# Altium schematic sheet Proximity Sensors.SchDoc("U_Proximity Sensors4")
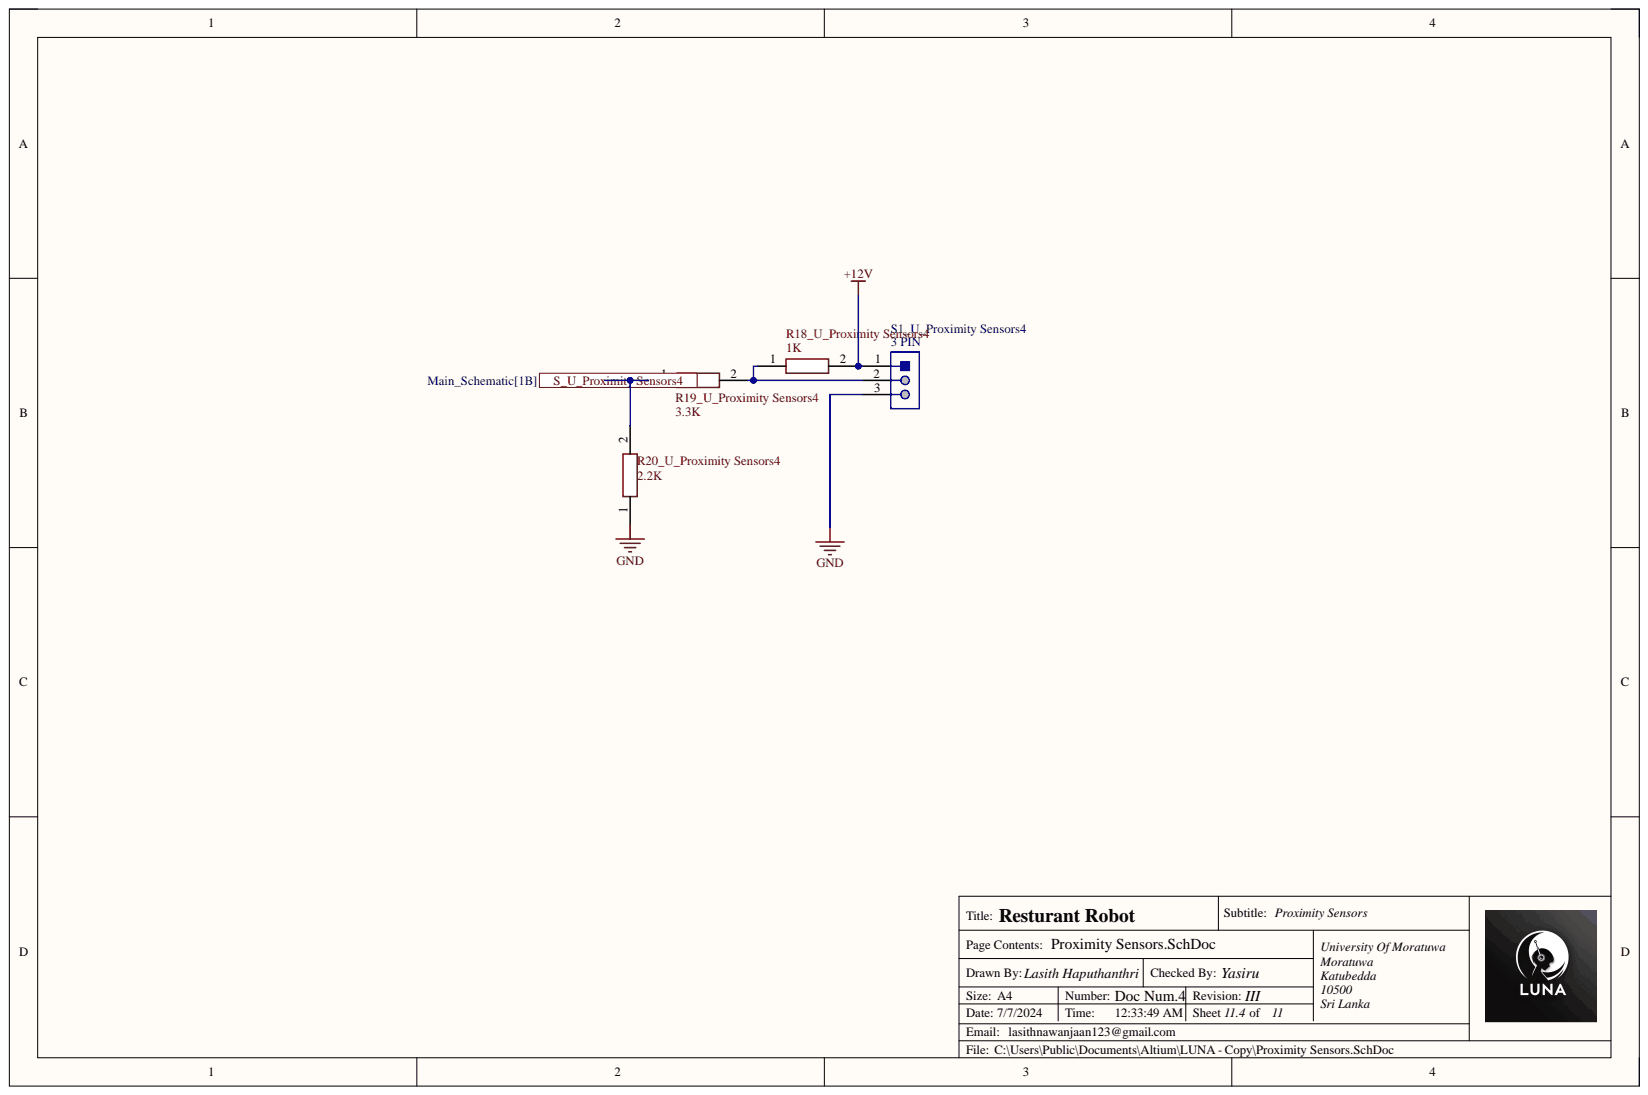
[diagram p0: Proximity Sensors.SchDoc]

## Parts
R18_U_Proximity_Sensors4  1K  [RESC1608X55N]
R19_U_Proximity_Sensors4  3.3K  [RESC1608X55N]
R20_U_Proximity_Sensors4  2.2K  [ERJ3EKF1000V]
S1_U_Proximity_Sensors4  3 PIN  [TECO-171825-3_V]

## Ports
S_U_Proximity Sensors4

## Nets
+12V: R18_U_Proximity_Sensors4.2 S1_U_Proximity_Sensors4.1
GND: R20_U_Proximity_Sensors4.1 S1_U_Proximity_Sensors4.3
NetR18_U_Proximity Sensors4_1: R18_U_Proximity_Sensors4.1 R19_U_Proximity_Sensors4.2 S1_U_Proximity_Sensors4.2
NetR19_U_Proximity Sensors4_1: R19_U_Proximity_Sensors4.1 R20_U_Proximity_Sensors4.2

## Library data of the parts
R18_U_Proximity_Sensors4 = RC0603FR-071KL: Resistor; Manufacturer_Name: YAGEO; Arrow Part Number: RC0603FR-071KL; Arrow Price/Stock: https://www.arrow.com/en/products/rc0603fr-071kl/yageo?region=nac; Component Kind: Standard; Datasheet Link: https://www.yageo.com/upload/media/product/productsearch/datasheet/rchip/PYu-RC_Group_51_RoHS_L_12.pdf; Height: 0.55mm; Library Name: SamacSys.SchLib; Manufacturer_Part_Number: RC0603FR-071KL; Mouser Part Number: 603-RC0603FR-071KL; Mouser Price/Stock: https://www.mouser.co.uk/ProductDetail/YAGEO/RC0603FR-071KL?qs=VU8sRB4EgwApHsk4rF%2F3zg%3D%3D
R19_U_Proximity_Sensors4 = RMCF0603JT3K30: Resistor RMCF0603JT3K30; Manufacturer_Name: Stackpole Electronics; Component Kind: Standard; Datasheet Link: https://www.seielect.com/Catalog/SEI-RMCF_RMCP.pdf; Height: 0.55mm; Manufacturer_Part_Number: RMCF0603JT3K30
R20_U_Proximity_Sensors4 = ERJ3GEYJ222V: Resistor; Manufacturer_Name: Panasonic; Arrow Part Number: ERJ3GEYJ222V; Arrow Price/Stock: https://www.arrow.com/en/products/erj-3geyj222v/panasonic?region=nac; Component Kind: Standard; Datasheet Link: http://docs-europe.electrocomponents.com/webdocs/13ba/0900766b813ba21b.pdf; Height: 0.55mm; Manufacturer_Part_Number: ERJ3GEYJ222V
S1_U_Proximity_Sensors4 = CMP-2000-07494-1: Male Header, Pitch 2.5 mm, 1 x 3 Position, Height 10.4 mm, Tail Length 3.6 mm,   -20 to 95 degC  , RoHS, Bulk; Manufacturer: TE Connectivity; Approvals: UL; Backwall/Post Interruptions: No(Without); Contact Resistance: 20mOhm; Mating Alignment: Yes(With); Mating Post Length: 7.5mm; Max Current Rating: 3.5A; Max Voltage Rating (AC): 250V; Max Voltage Rating (DC): 350.25kV; Number of Contacts: 3; PCB Mount Retention: Without; PCB Mounting Orientation: Vertical; PCB Thickness: 0.063inch; Pitch: 2.5mm; Plating Thickness: 0.8um; Tail Length: 0.142inch; Voltage Rating: 350V; Colour: Natural; Component Kind: Standard; Connector Type: Header; Contact Gender: Male; Contact Material: Brass; Contact Mating Length: 0.295inch; Contact Plating: Tin; Contact Retention: No(Without); Contact Shape: Square; Datasheet URL: http://www.te.com/commerce/DocumentDelivery/DDEController?Action=showdoc&DocId=Customer+Drawing%7F171825%7FY3%7Fpdf%7FEnglish%7FENG_CD_171825_Y3.pdf%7F171825-3; Datasheet Version: Rev. Y3, 12/2016; Depth: 4.9mm; Flammability Rating: UL94V-0; Height: 0.409inch; Housing Colour: Natural; Housing Material: Nylon; Length: 7.5mm; Library Name: Altium Content Vault; Manufacturer URL: http://www.te.com/; Mating Retention: Yes(With); Max Operating Temperature: 95degC; Min Operating Temperature: -20degC; Mount: ThroughHole; Mounting Technology: ThroughHole; Number of Positions: 3; Number of Rows: 1; Orientation: Straight; Package Description: Male Header, Pitch 2.5 mm, 1 x 3 Position, Height 10.4 mm, Tail Length 3.6 mm; Package Quantity: 1000; Package Reference: 171825-3; Packaging: Bag; Panel Mount Retention: No(Without); PCB Mount Alignment: No(Without); REACH SVHC: NoSVHC; RoHSCompliant: True; Strain Relief: No(Without); Termination: Solder
